# Altium schematic sheet 03.ESP32 IO.SchDoc
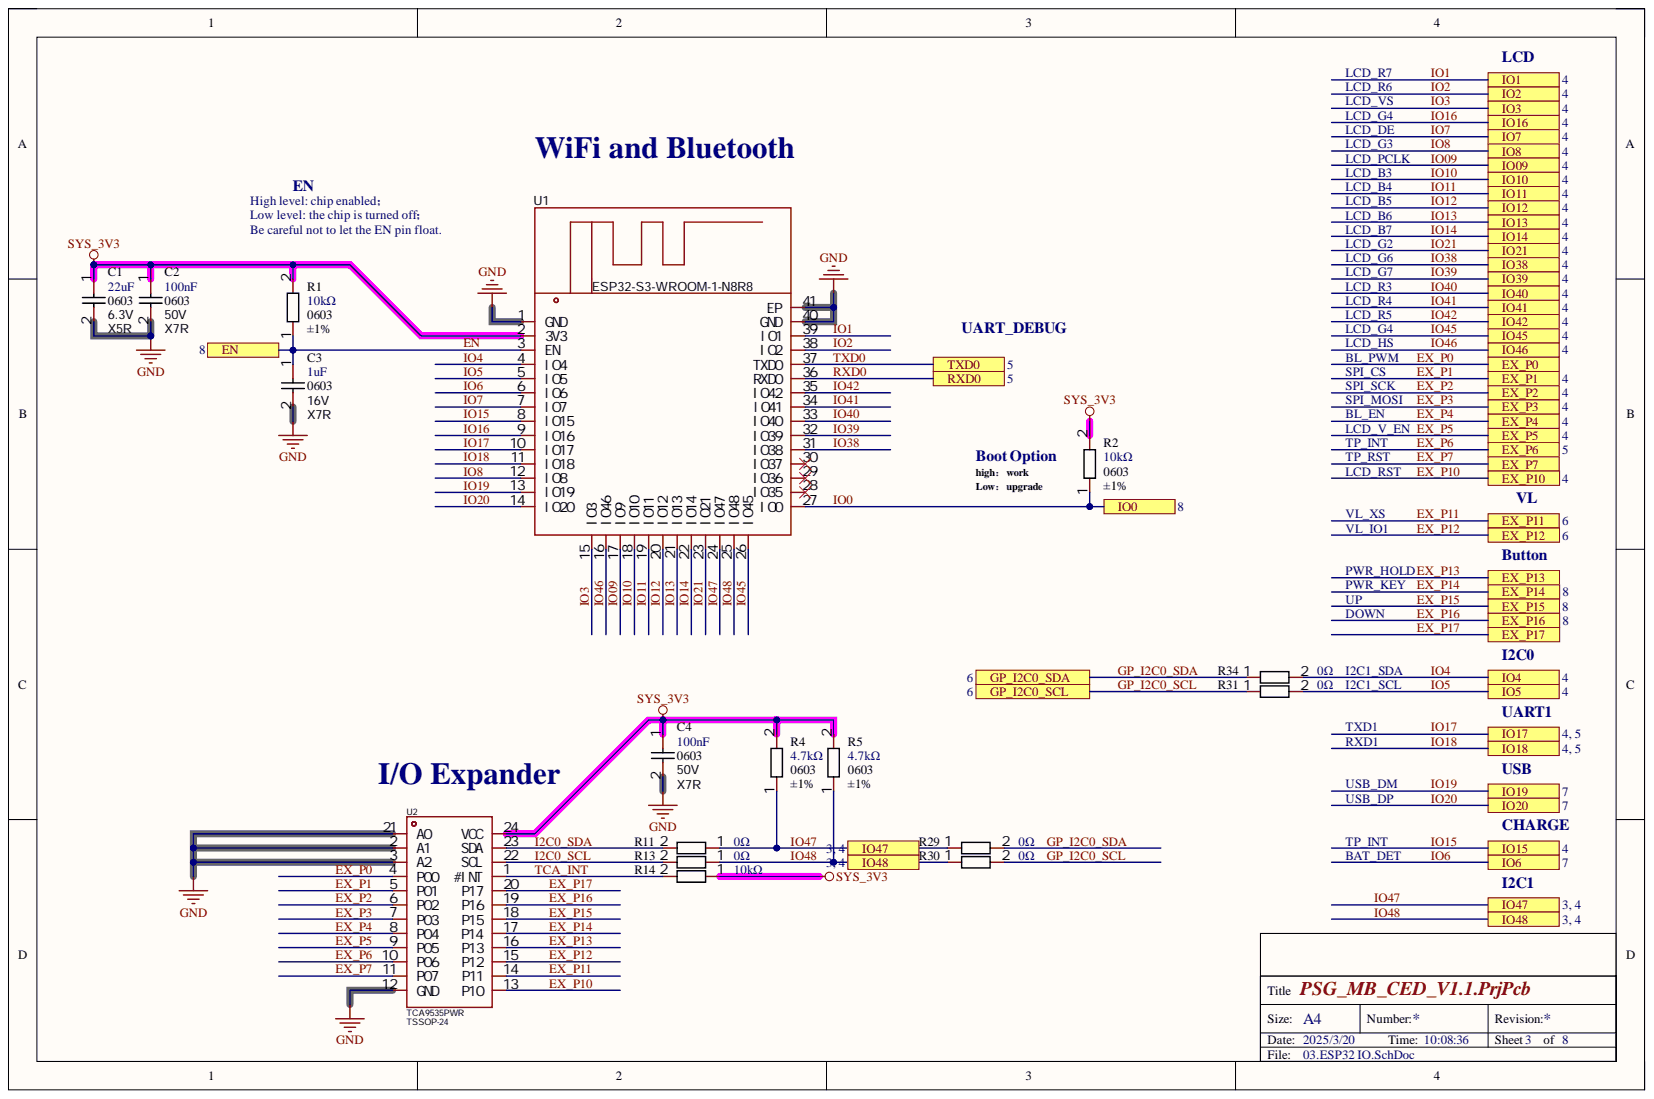
[diagram p0: 03.ESP32 IO.SchDoc]

## Parts
C1  22uF  Supplier Footprint: 0603  Temperature Coefficient: X5R  Voltage Rated: 6.3V  [C0603]
C2  100nF  Supplier Footprint: 0603  Temperature Coefficient: X7R  Voltage Rated: 50V  [C0603]
C3  1uF  Supplier Footprint: 0603  Temperature Coefficient: X7R  Voltage Rated: 16V  [C0603]
C4  100nF  Supplier Footprint: 0603  Temperature Coefficient: X7R  Voltage Rated: 50V  [C0603]
R1  10kΩ  Supplier Footprint: 0603  Tolerance: ±1%  [R0603]
R2  10kΩ  Supplier Footprint: 0603  Tolerance: ±1%  [R0603]
R4  4.7kΩ  Supplier Footprint: 0603  Tolerance: ±1%  [R0603]
R5  4.7kΩ  Supplier Footprint: 0603  Tolerance: ±1%  [R0603]
R11  0Ω  [R0603]
R13  0Ω  [R0603]
R14  10kΩ  [R0603]
R29  0Ω  [R0603]
R30  0Ω  [R0603]
R31  0Ω  [R0603]
R34  0Ω  [R0603]
U1  ESP32-S3-WROOM-1-N8R8  [SMD_ESP32-S3-WROOM-1]
U2  TCA9535PWR  Supplier Footprint: TSSOP-24  [TSSOP-24_7R8X4R4X0R65]

## Ports
EN, EX_P0, EX_P1, EX_P2, EX_P3, EX_P4, EX_P5, EX_P6, EX_P7, EX_P10, EX_P11, EX_P12, EX_P13, EX_P14, EX_P15, EX_P16, EX_P17, GP_I2C0_SCL, GP_I2C0_SDA, IO0, IO1, IO2, IO3, IO4, IO5, IO6, IO7, IO8, IO09, IO10, IO11, IO12, IO13, IO14, IO15, IO16, IO17, IO18, IO19, IO20, IO21, IO38, IO39, IO40, IO41, IO42, IO45, IO46, IO47, IO48, RXD0, TXD0

## Nets
GND: C1.2 C2.2 C3.2 C4.2 U1.1(GND) U1.40(GND) U1.41(EP) U2.2(A1) U2.3(A2) U2.12(GND) U2.21(A0)
SYS_3V3: C1.1 C2.1 C4.1 R1.2 R2.2 R4.2 R5.2 R14.1 U1.2(3V3) U2.24(VCC)
EN: C3.1 R1.1 U1.3(EN) ; port EN
EX_P0: U2.4(P00) ; port EX_P0
EX_P1: U2.5(P01) ; port EX_P1
EX_P2: U2.6(P02) ; port EX_P2
EX_P3: U2.7(P03) ; port EX_P3
EX_P4: U2.8(P04) ; port EX_P4
EX_P5: U2.9(P05) ; port EX_P5
EX_P6: U2.10(P06) ; port EX_P6
EX_P7: U2.11(P07) ; port EX_P7
EX_P10: U2.13(P10) ; port EX_P10
EX_P11: U2.14(P11) ; port EX_P11
EX_P12: U2.15(P12) ; port EX_P12
EX_P13: U2.16(P13) ; port EX_P13
EX_P14: U2.17(P14) ; port EX_P14
EX_P15: U2.18(P15) ; port EX_P15
EX_P16: U2.19(P16) ; port EX_P16
EX_P17: U2.20(P17) ; port EX_P17
GP_I2C0_SCL: R30.2 R31.1 ; port GP_I2C0_SCL
GP_I2C0_SDA: R29.2 R34.1 ; port GP_I2C0_SDA
I2C0_SCL: R13.2 U2.22(SCL)
I2C0_SDA: R11.2 U2.23(SDA)
IO0: R2.1 U1.27(IO0) ; port IO0
IO1: U1.39(IO1) ; port IO1
IO2: U1.38(IO2) ; port IO2
IO3: U1.15(IO3) ; port IO3
IO4: R34.2 U1.4(IO4) ; port IO4
IO5: R31.2 U1.5(IO5) ; port IO5
IO6: U1.6(IO6) ; port IO6
IO7: U1.7(IO7) ; port IO7
IO8: U1.12(IO8) ; port IO8
IO09: U1.17(IO9) ; port IO09
IO10: U1.18(IO10) ; port IO10
IO11: U1.19(IO11) ; port IO11
IO12: U1.20(IO12) ; port IO12
IO13: U1.21(IO13) ; port IO13
IO14: U1.22(IO14) ; port IO14
IO15: U1.8(IO15) ; port IO15
IO16: U1.9(IO16) ; port IO16
IO17: U1.10(IO17) ; port IO17
IO18: U1.11(IO18) ; port IO18
IO19: U1.13(IO19) ; port IO19
IO20: U1.14(IO20) ; port IO20
IO21: U1.23(IO21) ; port IO21
IO38: U1.31(IO38) ; port IO38
IO39: U1.32(IO39) ; port IO39
IO40: U1.33(IO40) ; port IO40
IO41: U1.34(IO41) ; port IO41
IO42: U1.35(IO42) ; port IO42
IO45: U1.26(IO45) ; port IO45
IO46: U1.16(IO46) ; port IO46
IO47: R4.1 R11.1 R29.1 U1.24(IO47) ; port IO47
IO48: R5.1 R13.1 R30.1 U1.25(IO48) ; port IO48
RXD0: U1.36(RXD0) ; port RXD0
TCA_INT: R14.2 U2.1(#INT)
TXD0: U1.37(TXD0) ; port TXD0

Not connected: U1 28(IO35) 29(IO36) 30(IO37)

## Library data of the parts
C1 = CC0603JRNPO9BN120: Manufacturer: YAGEO(国巨); Add into BOM: yes; Device: CC0603MRX5R5BB226; Name: 贴片电容; Tolerance: ±20%; Component Kind: Standard; Convert to PCB: yes; Datasheet: https://atta.szlcsc.com/upload/public/pdf/source/20180326/C109448_EB54A0A53A47321976FFDA89D54F692E.pdf; JLCPCB Part Class: Extended Part; LCSC Part Name: 22uF ±20% 6.3V; Manufacturer Part: CC0603MRX5R5BB226; Supplier: LCSC; Supplier Part: C109448; Symbol: CC0603MRX5R5BB226; Value: 22uF
C2 C4 = CC0603JRNPO9BN120: Manufacturer: YAGEO(国巨); Add into BOM: yes; Device: CC0603KRX7R9BB104; Name: 贴片电容; Tolerance: ±10%; Component Kind: Standard; Convert to PCB: yes; Datasheet: https://atta.szlcsc.com/upload/public/pdf/source/20180910/C282072_33301C7F631E79921B82A8DAC6BCC5B3.pdf; JLCPCB Part Class: Basic Part; LCSC Part Name: 100nF ±10% 50V; Manufacturer Part: CC0603KRX7R9BB104; Supplier: LCSC; Supplier Part: C14663; Symbol: CC0603KRX7R9BB104; Value: 100nF
C3 = CC0603JRNPO9BN120: Manufacturer: YAGEO(国巨); Add into BOM: yes; Device: CC0603KRX7R7BB105; Name: 贴片电容; Tolerance: ±10%; Component Kind: Standard; Convert to PCB: yes; Datasheet: https://atta.szlcsc.com/upload/public/pdf/source/20180910/C282072_33301C7F631E79921B82A8DAC6BCC5B3.pdf; JLCPCB Part Class: Extended Part; LCSC Part Name: 1uF ±10% 16V; Manufacturer Part: CC0603KRX7R7BB105; Supplier: LCSC; Supplier Part: C106248; Symbol: CC0603KRX7R7BB105; Value: 1uF
R1 R2 R14 = 0603WAF1003T5E: Manufacturer: YAGEO(国巨); Add into BOM: yes; Device: RC0603FR-0710KL; Name: 贴片电阻; Overload Voltage (Max): 75V; Power(Watts): 100mW; Temperature Coefficient: ±100ppm/℃; Component Kind: Standard; Convert to PCB: yes; Datasheet: https://atta.szlcsc.com/upload/public/pdf/source/20180927/C295883_E8D83085EA81BD7DE0B2B681138C15EE.pdf; JLCPCB Part Class: Extended Part; LCSC Part Name: 厚膜电阻 10kΩ ±1% 100mW; Manufacturer Part: RC0603FR-0710KL; Operating Temperature Range: -55℃~+155℃; Supplier: LCSC; Supplier Part: C98220; Symbol: RC0603FR-0710KL; Type: 厚膜电阻; Value: 10kΩ
R4 R5 = 0603WAF1003T5E: Manufacturer: YAGEO(国巨); Add into BOM: yes; Device: RC0603FR-074K7L; Name: 贴片电阻; Overload Voltage (Max): 75V; Power(Watts): 100mW; Temperature Coefficient: ±100ppm/℃; Component Kind: Standard; Convert to PCB: yes; Datasheet: https://atta.szlcsc.com/upload/public/pdf/source/20180224/C181757_15194369480721363983.pdf; JLCPCB Part Class: Extended Part; LCSC Part Name: 厚膜电阻 4.7kΩ ±1% 100mW; Manufacturer Part: RC0603FR-074K7L; Operating Temperature Range: -55℃~+155℃; Supplier: LCSC; Supplier Part: C99782; Symbol: RC0603FR-074K7L; Type: 厚膜电阻; Value: 4.7kΩ
R11 R13 R29-R31 R34 = 0603WAF1003T5E: Manufacturer: YAGEO(国巨); Add into BOM: yes; Device: RC0603FR-070RL; Name: 贴片电阻; Overload Voltage (Max): 75V; Power(Watts): 100mW; Component Kind: Standard; Convert to PCB: yes; Datasheet: https://atta.szlcsc.com/upload/public/pdf/source/20180316/70C0E2BDAE7FCC62C531A5A58D202EE1.pdf; JLCPCB Part Class: Extended Part; LCSC Part Name: 厚膜电阻 0Ω ±1% 100mW; Manufacturer Part: RC0603FR-070RL; Operating Temperature Range: -55℃~+155℃; Supplier: LCSC; Supplier Part: C100044; Symbol: RC0603FR-070RL; Temperature Coefficient: -; Type: 厚膜电阻; Value: 0Ω
U1 = ESP32-S3-WROOM-1-N8: Manufacturer: ESPRESSIF(乐鑫); Add into BOM: yes; Core Processor: ESP32-S3芯片; Device: ESP32-S3-WROOM-1-N4; Support Interface: I2C；GPIO；SPI；PWM；ADC；UART；USB；I2S; Symbol: ESP32-S3-WROOM-1(N8R8); Component Kind: Standard; Convert to PCB: yes; Datasheet: https://atta.szlcsc.com/upload/public/pdf/source/20241030/6A412B13087E1327DF6279F5735253CA.pdf; JLCPCB Part Class: Extended Part; LCSC Part Name: ESP32-S3-WROOM-1-N8R8; Library Name: PCB_Project.SCHLIB; Manufacturer Part: ESP32-S3-WROOM-1-N8R8; PCB3D: WIFIM-SMD_ESP32-S3-WROOM-1-N8; Supplier: LCSC; Supplier Part: C2913201; Value: ESP32-S3-WROOM-1-N8R8
U2 = TCA9535PWR: Manufacturer: TI(德州仪器); Add into BOM: yes; Clock Frequency: 400kHz; Interface: I2C；SMBus; Interrupt Output: 有中断输出; Number of I/O: 16; Output Sink Current: 25mA; Output Source current: 10mA; Output Type: 推挽式; Supply Voltage: 1.65V~5.5V; Symbol: TCA9535PWR_C130204; Component Kind: Standard; Convert to PCB: yes; Datasheet: https://www.ti.com/cn/lit/gpn/tca9535; JLCPCB Part Class: Extended Part; LCSC Part Name: TCA9535PWR; Manufacturer Part: TCA9535PWR; Operating Temperature: -40℃~+85℃; PCB3D: TSSOP-24_L7.8-W4.4-H1.0-LS6.4-P0.65; Supplier: LCSC; Supplier Part: C130204; Value: TCA9535PWR
